# Altium schematic sheet DSPY&KEY.SchDoc(DSPY&KEY)
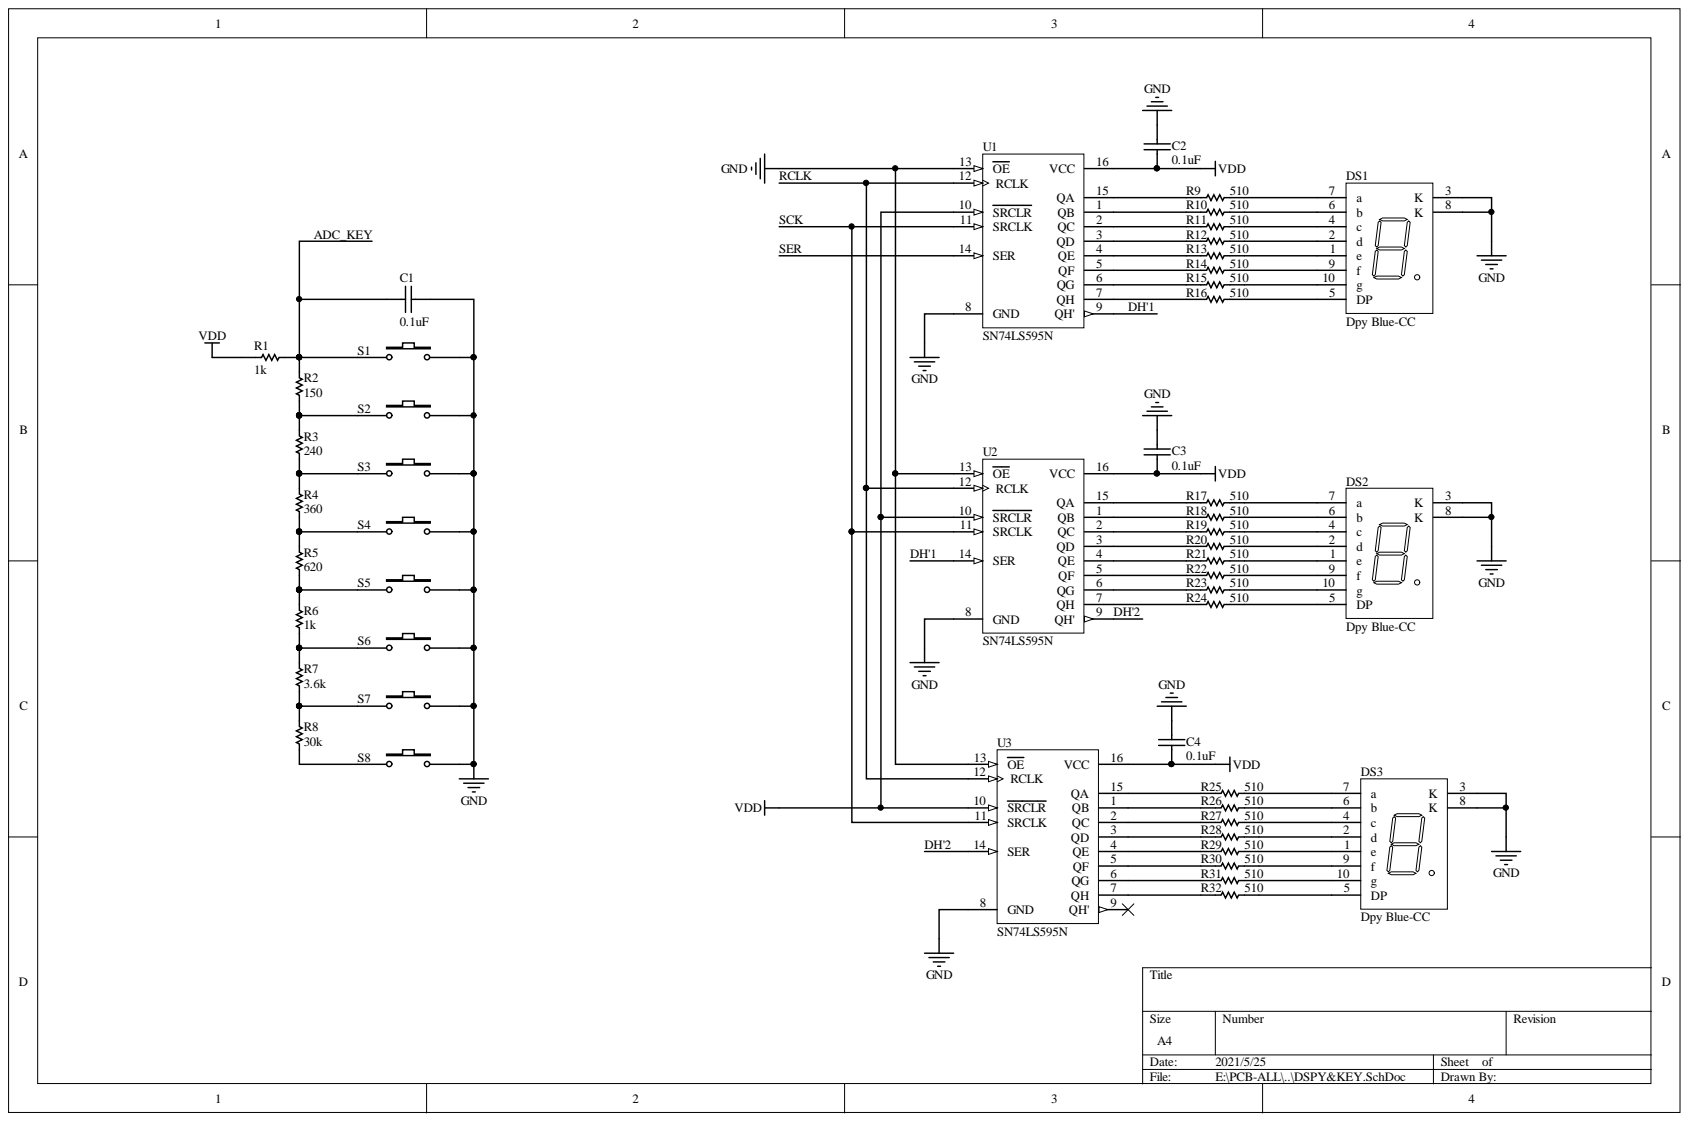
[diagram p0: DSPY&KEY.SchDoc]

## Parts
C1  0.1uF  [C0805]
C2  0.1uF  [C0805]
C3  0.1uF  [C0805]
C4  0.1uF  [C0805]
DS1  Dpy Blue-CC  [H]
DS2  Dpy Blue-CC  [H]
DS3  Dpy Blue-CC  [H]
R1  1k  [R0805]
R2  150  [R0805]
R3  240  [R0805]
R4  360  [R0805]
R5  620  [R0805]
R6  1k  [R0805]
R7  3.6k  [R0805]
R8  30k  [R0805]
R9  510  [R0603]
R10  510  [R0603]
R11  510  [R0603]
R12  510  [R0603]
R13  510  [R0603]
R14  510  [R0603]
R15  510  [R0603]
R16  510  [R0603]
R17  510  [R0603]
R18  510  [R0603]
R19  510  [R0603]
R20  510  [R0603]
R21  510  [R0603]
R22  510  [R0603]
R23  510  [R0603]
R24  510  [R0603]
R25  510  [R0603]
R26  510  [R0603]
R27  510  [R0603]
R28  510  [R0603]
R29  510  [R0603]
R30  510  [R0603]
R31  510  [R0603]
R32  510  [R0603]
S1  SW-PB  [SW-BTN4 - SMT]  (value not drawn)
S2  SW-PB  [SW-BTN4 - SMT]  (value not drawn)
S3  SW-PB  [SW-BTN4 - SMT]  (value not drawn)
S4  SW-PB  [SW-BTN4 - SMT]  (value not drawn)
S5  SW-PB  [SW-BTN4 - SMT]  (value not drawn)
S6  SW-PB  [SW-BTN4 - SMT]  (value not drawn)
S7  SW-PB  [SW-BTN4 - SMT]  (value not drawn)
S8  SW-PB  [SW-BTN4 - SMT]  (value not drawn)
U1  SN74LS595N  [SO-16_N]
U2  SN74LS595N  [SO-16_N]
U3  SN74LS595N  [SO-16_N]

## Nets
GND: C1.2 C2.1 C3.1 C4.1 DS1.3(K) DS1.8(K) DS2.3(K) DS2.8(K) DS3.3(K) DS3.8(K) S1.2 S2.2 S3.2 S4.2 S5.2 S6.2 S7.2 S8.2 U1.8(GND) U1.13(OE) U2.8(GND) U2.13(OE) U3.8(GND) U3.13(OE)
VDD: C2.2 C3.2 C4.2 R1.1 U1.10(SRCLR) U1.16(VCC) U2.10(SRCLR) U2.16(VCC) U3.10(SRCLR) U3.16(VCC)
ADC_KEY: C1.1 R1.2 R2.1 S1.1
DH'1: U1.9(QH') U2.14(SER)
DH'2: U2.9(QH') U3.14(SER)
RCLK: U1.12(RCLK) U2.12(RCLK) U3.12(RCLK)
SCK: U1.11(SRCLK) U2.11(SRCLK) U3.11(SRCLK)
SER: U1.14(SER)
NetDS1_1: DS1.1(e) R13.1
NetDS1_2: DS1.2(d) R12.1
NetDS1_4: DS1.4(c) R11.1
NetDS1_5: DS1.5(DP) R16.1
NetDS1_6: DS1.6(b) R10.1
NetDS1_7: DS1.7(a) R9.1
NetDS1_9: DS1.9(f) R14.1
NetDS1_10: DS1.10(g) R15.1
NetDS2_1: DS2.1(e) R21.1
NetDS2_2: DS2.2(d) R20.1
NetDS2_4: DS2.4(c) R19.1
NetDS2_5: DS2.5(DP) R24.1
NetDS2_6: DS2.6(b) R18.1
NetDS2_7: DS2.7(a) R17.1
NetDS2_9: DS2.9(f) R22.1
NetDS2_10: DS2.10(g) R23.1
NetDS3_1: DS3.1(e) R29.1
NetDS3_2: DS3.2(d) R28.1
NetDS3_4: DS3.4(c) R27.1
NetDS3_5: DS3.5(DP) R32.1
NetDS3_6: DS3.6(b) R26.1
NetDS3_7: DS3.7(a) R25.1
NetDS3_9: DS3.9(f) R30.1
NetDS3_10: DS3.10(g) R31.1
NetR2_2: R2.2 R3.1 S2.1
NetR3_2: R3.2 R4.1 S3.1
NetR4_2: R4.2 R5.1 S4.1
NetR5_2: R5.2 R6.2 S5.1
NetR6_1: R6.1 R7.2 S6.1
NetR7_1: R7.1 R8.2 S7.1
NetR8_1: R8.1 S8.1
NetR9_2: R9.2 U1.15(QA)
NetR10_2: R10.2 U1.1(QB)
NetR11_2: R11.2 U1.2(QC)
NetR12_2: R12.2 U1.3(QD)
NetR13_2: R13.2 U1.4(QE)
NetR14_2: R14.2 U1.5(QF)
NetR15_2: R15.2 U1.6(QG)
NetR16_2: R16.2 U1.7(QH)
NetR17_2: R17.2 U2.15(QA)
NetR18_2: R18.2 U2.1(QB)
NetR19_2: R19.2 U2.2(QC)
NetR20_2: R20.2 U2.3(QD)
NetR21_2: R21.2 U2.4(QE)
NetR22_2: R22.2 U2.5(QF)
NetR23_2: R23.2 U2.6(QG)
NetR24_2: R24.2 U2.7(QH)
NetR25_2: R25.2 U3.15(QA)
NetR26_2: R26.2 U3.1(QB)
NetR27_2: R27.2 U3.2(QC)
NetR28_2: R28.2 U3.3(QD)
NetR29_2: R29.2 U3.4(QE)
NetR30_2: R30.2 U3.5(QF)
NetR31_2: R31.2 U3.6(QG)
NetR32_2: R32.2 U3.7(QH)

Not connected: U3 9(QH')

## Library data of the parts
C1-C4 = CAP: Capacitor; 中文名称: 贴片电容; Component Kind: Standard; Library Name: 2014.SchLib
DS1-DS3 = Dpy Blue-CC: 14.2 mm General Purpose Blue 7-Segment Display: CC, RH DP, Gray Surface; MinimumIntensity: 2020 ucd; Note: IF = 10 mA; Colour: Blue; Component Kind: Standard; ComponentLink1Description: Manufacturer Link; ComponentLink1URL: http://www.agilent.com/; DatasheetVersion: 24-Sep-2002; LatestRevisionDate: 17-Dec-2003; LatestRevisionNote: Schematic revised, PCB Footprint replaced.; Library Name: Miscellaneous Devices.IntLib; PackageReference: H; PackageVersion: 24-Sep-2002; Published: 8-Jun-2000; Simulation: HDSP_503B; Publisher: Altium Limited
R1 R6 = Res1: Resistor; Component Kind: Standard; Library Name: 2014.SchLib; Published: 8-Jun-2000; Revision: July-2002: Re-released for DXP Platform.; Signal Integrity: Res; Simulation: RESISTOR; Value: 1K; Publisher: Altium Limited
R2-R5 R7-R32 = Res1: Resistor; Value: 1K; Component Kind: Standard; Library Name: 2014.SchLib; Published: 8-Jun-2000; Revision: July-2002: Re-released for DXP Platform.; Signal Integrity: Res; Simulation: RESISTOR; Publisher: Altium Limited
S1-S8 = SW-PB: Switch; Component Kind: Standard; LatestRevisionDate: 17-Jul-2002; LatestRevisionNote: Re-released for DXP Platform.; Library Name: Miscellaneous Devices.IntLib; PackageReference: SPST-2; Published: 24-Mar-1999; Publisher: Altium Limited
U1-U3 = SN74LS595N: 8-Bit Shift Register with Output Latch; Code_JEDEC: MS-001-BB; Component Kind: Standard; ComponentLink1Description: Manufacturer Link; ComponentLink1URL: http://www.ti.com/; ComponentLink2Description: Datasheet; ComponentLink2URL: http://www-s.ti.com/sc/ds/sn74ls596.pdf; DatasheetVersion: Mar-1988; LatestRevisionDate: 04-Mar-2005; LatestRevisionNote: Stylized 3D Model Added.; Library Name: TI Logic Register.IntLib; PackageDescription: DIP; 16 Leads; Row Spacing 7.62 mm; Pitch 2.54 mm; PackageReference: N016; PackageVersion: Oct-1995; PCB3D: N016; Published: 8-Jun-2000; Signal Integrity: SN74LS595N; Publisher: Altium Limited
